# Altium schematic sheet 4_Video.schdoc(4_Video)
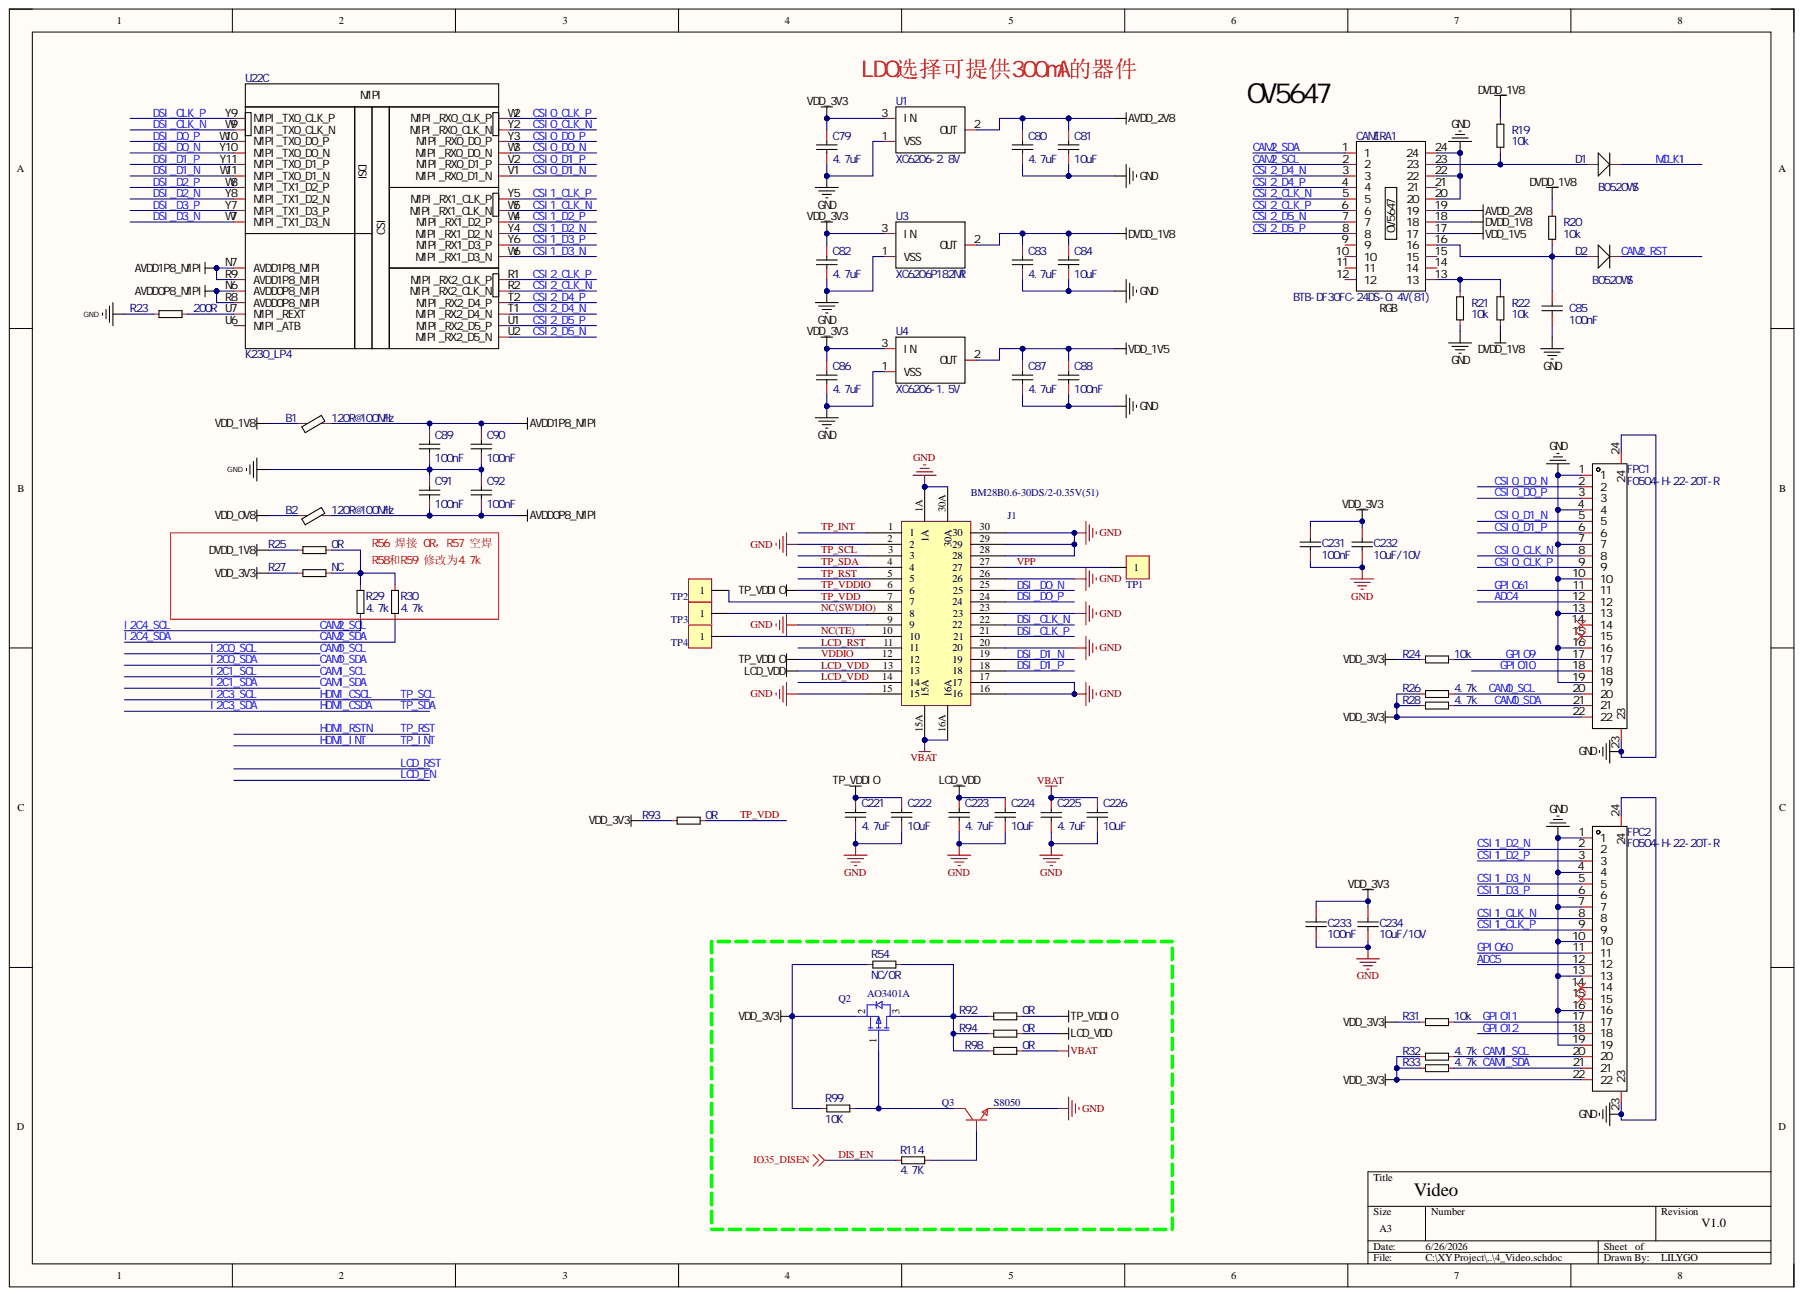
[diagram p0: 4_Video.schdoc]

## Parts
B1  120R@100MHz  [R0201]
B2  120R@100MHz  [R0201]
C79  4.7uF  [c0402]
C80  4.7uF  [c0402]
C81  10uF  [c0402]
C82  4.7uF  [c0402]
C83  4.7uF  [c0402]
C84  10uF  [c0402]
C85  100nF  [c0402]
C86  4.7uF  [c0402]
C87  4.7uF  [c0402]
C88  100nF  [c0402]
C89  100nF  [C0201]
C90  100nF  [C0201]
C91  100nF  [C0201]
C92  100nF  [C0201]
C221  4.7uF  [c0402]
C222  10uF  [c0402]
C223  4.7uF  [c0402]
C224  10uF  [c0402]
C225  4.7uF  [c0402]
C226  10uF  [c0402]
C231  100nF  [c0402]
C232  10uF/10V  [c0603]
C233  100nF  [c0402]
C234  10uF/10V  [c0603]
CAMERA1  BTB-DF30FC-24DS-0.4V(81)  [BTB-DF30FC-24DS-0.4V(81)]
D1  B0520WS  [SOD-523]
D2  B0520WS  [SOD-523]
FPC1  F0504-H-22-20T-R  [FPC-SMD_22P-P0.50_FPC-05F-22PH20]
FPC2  F0504-H-22-20T-R  [FPC-SMD_22P-P0.50_FPC-05F-22PH20]
J1  BM28B0.6-30DS/2-0.35V(51)  [CONN-SMD_BM28B0.6-30DS-2-0.35V-51]
Q2  AO3401A  [SOT-23_L2.9-W1.3-P1.90-LS2.4-BR]
Q3  S8050  [SOT-23-3_L2.9-W1.3-P1.90-LS2.3-BR]
R19  10k  [r0402]
R20  10k  [r0402]
R21  10k  [r0402]
R22  10k  [r0402]
R23  200R  [r0402]
R24  10k  [r0402]
R25  0R  [r0402]
R26  4.7k  [r0402]
R27  NC  [r0402]
R28  4.7k  [r0402]
R29  4.7k  [r0402]
R30  4.7k  [r0402]
R31  10k  [r0402]
R32  4.7k  [r0402]
R33  4.7k  [r0402]
R54  NC/0R  [R0603]
R92  0R  [r0402]
R93  0R  [r0402]
R94  0R  [r0402]
R98  0R  [r0402]
R99  10K  [r0402]
R114  4.7K  [r0402]
TP1  HDR-1X1  [TP1.0]  (value not drawn)
TP2  HDR-1X1  [TP1.0]  (value not drawn)
TP3  HDR-1X1  [TP1.0]  (value not drawn)
TP4  HDR-1X1  [TP1.0]  (value not drawn)
U1  XC6206-2.8V  [SOT23-3]
U2  CAM_OV5647  [CAM_OV5647]  (value not drawn)
U3  XC6206P182MR  [SOT23-3]
U4  XC6206-1.5V  [SOT23-3]
U22  K230_LP4  [K230]  (part C)

## Nets
AVDD0P8_MIPI: B2.2 C91.2 C92.2 U22.N6(AVDD0P8_MIPI) U22.R8(AVDD0P8_MIPI)
AVDD1P8_MIPI: B1.2 C89.1 C90.1 U22.N7(AVDD1P8_MIPI) U22.R9(AVDD1P8_MIPI)
AVDD_2V8: C80.1 C81.1 CAMERA1.19 U1.2
DVDD_1V8: C83.1 C84.1 CAMERA1.18 R19.1 R20.1 R22.2 R25.1 U3.2
GND: C79.2 C80.2 C81.2 C82.2 C83.2 C84.2 C85.2 C86.2 C87.2 C88.2 C89.2 C90.2 C91.1 C92.1 C221.2 C222.2 C223.2 C224.2 C225.2 C226.2 C231.1 C232.1 C233.1 C234.1 CAMERA1.20 CAMERA1.22 CAMERA1.24 FPC1.1 FPC1.4 FPC1.7 FPC1.10 FPC1.13 FPC1.16 FPC1.19 FPC1.23 FPC1.24 FPC2.1 FPC2.4 FPC2.7 FPC2.10 FPC2.13 FPC2.16 FPC2.19 FPC2.23 FPC2.24 J1.1A J1.2 J1.9 J1.15 J1.16 J1.17 J1.20 J1.23 J1.26 J1.28 J1.29 J1.30 J1.30A Q3.2 R21.2 R23.1 U1.1 U3.1 U4.1
VBAT: C225.1 C226.1 J1.15A J1.16A R98.2
VDD_0V8: B2.1
VDD_1V5: C87.1 C88.1 CAMERA1.17 U4.2
VDD_1V8: B1.1
VDD_3V3: C79.1 C82.1 C86.1 C231.2 C232.2 C233.2 C234.2 FPC1.22 FPC2.22 Q2.2 R24.1 R26.1 R27.1 R28.1 R31.1 R32.1 R33.1 R54.1 R93.1 R99.2 U1.3 U3.3 U4.3
ADC4: FPC1.12
ADC5: FPC2.12
CAM0_SCL: FPC1.20 R26.2
CAM0_SDA: FPC1.21 R28.2
CAM1_SCL: FPC2.20 R32.2
CAM1_SDA: FPC2.21 R33.2
CAM2_RST: D2.2
CAM2_SCL: CAMERA1.2 R29.2
CAM2_SDA: CAMERA1.1 R30.2
CSI0_CLK_N: FPC1.8 U22.Y2(MIPI_RX0_CLK_N)
CSI0_CLK_P: FPC1.9 U22.W2(MIPI_RX0_CLK_P)
CSI0_D0_N: FPC1.2 U22.W3(MIPI_RX0_D0_N)
CSI0_D0_P: FPC1.3 U22.Y3(MIPI_RX0_D0_P)
CSI0_D1_N: FPC1.5 U22.V1(MIPI_RX0_D1_N)
CSI0_D1_P: FPC1.6 U22.V2(MIPI_RX0_D1_P)
CSI1_CLK_N: FPC2.8 U22.W5(MIPI_RX1_CLK_N)
CSI1_CLK_P: FPC2.9 U22.Y5(MIPI_RX1_CLK_P)
CSI1_D2_N: FPC2.2 U22.Y4(MIPI_RX1_D2_N)
CSI1_D2_P: FPC2.3 U22.W4(MIPI_RX1_D2_P)
CSI1_D3_N: FPC2.5 U22.W6(MIPI_RX1_D3_N)
CSI1_D3_P: FPC2.6 U22.Y6(MIPI_RX1_D3_P)
CSI2_CLK_N: CAMERA1.5 U22.R2(MIPI_RX2_CLK_N)
CSI2_CLK_P: CAMERA1.6 U22.R1(MIPI_RX2_CLK_P)
CSI2_D4_N: CAMERA1.3 U22.T1(MIPI_RX2_D4_N)
CSI2_D4_P: CAMERA1.4 U22.T2(MIPI_RX2_D4_P)
CSI2_D5_N: CAMERA1.7 U22.U2(MIPI_RX2_D5_N)
CSI2_D5_P: CAMERA1.8 U22.U1(MIPI_RX2_D5_P)
DIS_EN: R114.2
DSI_CLK_N: J1.22 U22.W9(MIPI_TX0_CLK_N)
DSI_CLK_P: J1.21 U22.Y9(MIPI_TX0_CLK_P)
DSI_D0_N: J1.25 U22.Y10(MIPI_TX0_D0_N)
DSI_D0_P: J1.24 U22.W10(MIPI_TX0_D0_P)
DSI_D1_N: J1.19 U22.W11(MIPI_TX0_D1_N)
DSI_D1_P: J1.18 U22.Y11(MIPI_TX0_D1_P)
DSI_D2_N: U22.Y8(MIPI_TX1_D2_N)
DSI_D2_P: U22.W8(MIPI_TX1_D2_P)
DSI_D3_N: U22.W7(MIPI_TX1_D3_N)
DSI_D3_P: U22.Y7(MIPI_TX1_D3_P)
GPIO9: FPC1.17 R24.2
GPIO10: FPC1.18
GPIO11: FPC2.17 R31.2
GPIO12: FPC2.18
GPIO60: FPC2.11
GPIO61: FPC1.11
HDMI_CSCL: J1.3
HDMI_CSDA: J1.4
HDMI_INT: J1.1
HDMI_RSTN: J1.5
LCD_RST: J1.11
LCD_VDD: C223.1 C224.1 J1.13 J1.14 R94.2
MCLK1: D1.2
NC(SWDIO): J1.8 TP3.1
NC(TE): J1.10 TP4.1
TP_VDD: J1.7 R93.2 TP2.1
TP_VDDIO: C221.1 C222.1 J1.6 J1.12 R92.2
VPP: J1.27 TP1.1
NetC85_1: C85.1 CAMERA1.16 D2.1 R20.2
NetCAMERA1_13: CAMERA1.13 R21.1 R22.1
NetCAMERA1_23: CAMERA1.23 D1.1 R19.2
NetQ2_1: Q2.1 Q3.3 R99.1
NetQ2_3: Q2.3 R54.2 R92.1 R94.1 R98.1
NetQ3_1: Q3.1 R114.1
NetR23_2: R23.2 U22.U7(MIPI_REXT)
NetR25_2: R25.2 R27.2 R29.1 R30.1
LCD_EN:

Not connected: CAMERA1 9 10 11 12 14 15 21; FPC1 14 15; FPC2 14 15; U22 U6(MIPI_ATB)

## Library data of the parts
B1 B2 = BEAD: Add into BOM: yes; Comment_1: 120R@100MHz; Component Kind: Standard; Convert to PCB: yes; Device: BEAD; Symbol: BEAD
C79-C88 C221-C226 = CAP: Add into BOM: yes; Component Kind: Standard; Convert to PCB: yes; Device: CAP; Symbol: CAP
  C79 C80 C82 C83 C86 C87 C221 C223 C225: Comment_1: 4.7uF
  C81 C84 C222 C224 C226: Comment_1: 10uF
  C85 C88: Comment_1: 100nF
C89-C92 = 0201_CAP: Add into BOM: yes; Symbol: CAP; Comment_1: 100nF; Component Kind: Standard; Convert to PCB: yes; Device: 0201_CAP; PCB3D: 0201-CAP
C231-C234 = CAP: Add into BOM: yes; Comment_1: 22uF/10V; Component Kind: Standard; Convert to PCB: yes; Device: CAP; Symbol: CAP
CAMERA1 = BTB-DF30FC-24DS-0.4V(81): Add into BOM: yes; LC_CODE: C5137456; Origin Footprint 2: 12PINX2; Symbol: HEADER 12X2_V; Comment_1: BTB-DF30FC-24DS-0.4V(81); Component Kind: Standard; Convert to PCB: yes; Description: BTB-DF30FC-24DS-0.4V(81); Design Item ID: HEADER 12X2_V; Device: BTB-DF30FC-24DS-0.4V(81); Library Name: ZX_MyLib; Origin Footprint 1: BTB-DF30FC-24DS-0.4V(81); Origin Footprint 3: DF30FC-24DS-0.4V(81)
D1 D2 = Diode: Add into BOM: yes; Comment_1: B0520WS; Component Kind: Standard; Convert to PCB: yes; Device: Diode; Symbol: Diode
FPC1 FPC2 = FPC-05F-22PH20: 间距:0.5mm;触点数量:22P;锁定特性:翻盖式;触点类型:双侧触点/上下接;安装类型:卧贴;工作温度范围:-25℃~+85℃;; Manufacturer: XUNPU(讯普); Add into BOM: yes; FFC, FCB Thickness: 0.3mm; Locking Feature: 翻盖式; Number of Contacts: 22P; Pitch: 0.5mm; Comment_1: FPC-05F-22PH20; Component Kind: Standard; Contact Type: 下接; Convert to PCB: yes; Datasheet: https://atta.szlcsc.com/upload/public/pdf/source/20210922/C2856804_675295370E4BEA18823604D875A4450B.pdf; Device: FPC-05F-22PH20; Height Above Board: 2mm; LCSC Part Name: 间距:0.5mm P数:22P 翻盖式 下接; Manufacturer Part: FPC-05F-22PH20; Mounting Type: 卧贴; Operating Temperature Range: -25℃~+85℃; PCB3D: FPC-SMD_22P-P0.50_FPC-05F-22PH20; Supplier: LCSC; Supplier Footprint: SMD,P=0.5mm,卧贴; Supplier Part: C2856804; Symbol: FPC-05F-22PH20
J1 = BM28B0.6-30DP/2-0.35V(51): Component Kind: Standard; Library Name: CanMV-K230-LP4-V3.0.SCHLIB
Q2 = AO3401A_C15127: Manufacturer: AOS; Add into BOM: yes; Continuous Drain Current (Id): 4A; Drain Source On Resistance (RDS(on)@Vgs,Id): 44mΩ@10V,4.3A; Drain Source Voltage (Vdss): 30V; Gate Threshold Voltage (Vgs(th)@Id): 1.3V@250uA; Input Capacitance (Ciss@Vds): 1.2nF@15V; Power Dissipation (Pd): 1.4W; Total Gate Charge (Qg@Vgs): 12.2nC@4.5V; Type: 1个P沟道; Component Kind: Standard; Convert to PCB: yes; Datasheet: https://atta.szlcsc.com/upload/public/pdf/source/20160507/1462613609053.pdf; Device: AO3401A_C15127; JLCPCB Part Class: Basic Part; LCSC Part Name: 1个P沟道 耐压:30V 电流:4A; Manufacturer Part: AO3401A; Operating Temperature: -55℃~+150℃@(Tj); PCB3D: SOT-23-3P_L2.9-W1.3-H1.0-LS2.4-P0.95; Supplier: LCSC; Supplier Footprint: SOT-23; Supplier Part: C15127; Symbol: AO3401A; Library Name: WendyLib.SchLib
Q3 = S8050_C20069125: Manufacturer: 宏嘉诚; Add into BOM: yes; Collector - Emitter Voltage VCEO: 25V; Current - Collector Cutoff: 100nA; Current - Collector(Ic): 500mA; DC Current Gain: 120@50mA,1.0V; Pd - Power Dissipation: 300mW; Transition frequency(fT): 100MHz; type: NPN; Vce Saturation(VCE(sat)): 600mV; Component Kind: Standard; Convert to PCB: yes; Datasheet: https://atta.szlcsc.com/upload/public/pdf/source/20250107/343E8B94B5C24D0D65D7D4B70E0B73F6.pdf; Device: S8050_C20069125; JLCPCB Part Class: Extended Part; LCSC Part Name: hFE:200-350，丝印:J3Y S8050; Manufacturer Part: S8050; Operating Temperature: -55℃~+150℃; PCB3D: SOT-23-3_L2.9-W1.3-P1.90-LS2.3-BR; Supplier: LCSC; Supplier Footprint: SOT-23; Supplier Part: C20069125; Symbol: S8050_C20069125
R19-R33 R92-R94 R98 = RES: Add into BOM: yes; Component Kind: Standard; Convert to PCB: yes; Device: RES; Symbol: RES
  R19-R22 R24 R31: Comment_1: 10k
  R23: Comment_1: 200R
  R25 R92-R94 R98: Comment_1: 0R
  R26 R28-R30 R32 R33: Comment_1: 4.7k
  R27: Comment_1: NC
R54 = RES: Add into BOM: yes; Comment_1: 75R; Component Kind: Standard; Convert to PCB: yes; Device: RES; Symbol: RES
R99 R114 = RES: Add into BOM: yes; Comment_1: 0R; Component Kind: Standard; Convert to PCB: yes; Device: RES; Symbol: RES
TP1-TP4 = Header 1: 1P接插件; 版权归属: 源创客; BOM名称: 1P接插件; Component Kind: Standard; Library Name: 接插件.SchLib; 学习资源: www.ooocke.com
U1 U3 = XC6206-2.8V: XC6206 2.8V; Add into BOM: yes; LC_CODE: C2892669; Origin Footprint: SOT23-3_NSK; Comment_1: XC6206-2.8V; Component Kind: Standard; Convert to PCB: yes; Design Item ID: XC6206; Device: XC6206-2.8V; Library Name: ZX_MyLib; Symbol: XC6206
U2 = CAM_OV5647: Add into BOM: yes; Comment_1: CAM_OV5647; Component Kind: Standard; Convert to PCB: yes; Device: CAM_OV5647; PCB3D: OV5647; Symbol: CAM_OV5647
U4 = XC6206-1.5V: XC6206 1.5V; Add into BOM: yes; LC_CODE: C236709; Origin Footprint: SOT23-3_NSK; Comment_1: XC6206-1.5V; Component Kind: Standard; Convert to PCB: yes; Design Item ID: XC6206; Device: XC6206-1.5V; Library Name: ZX_MyLib; Symbol: XC6206
U22 = K230_LP4: Add into BOM: yes; Comment_1: K230_LP4; Component Kind: Standard; Convert to PCB: yes; Device: K230_LP4; Library Name: CanMV-K230-LP4-V3.0.SCHLIB; PCB3D: K230; Symbol: K230_LP4
